# Altium schematic sheet Sheet1.SchDoc(Sheet1)
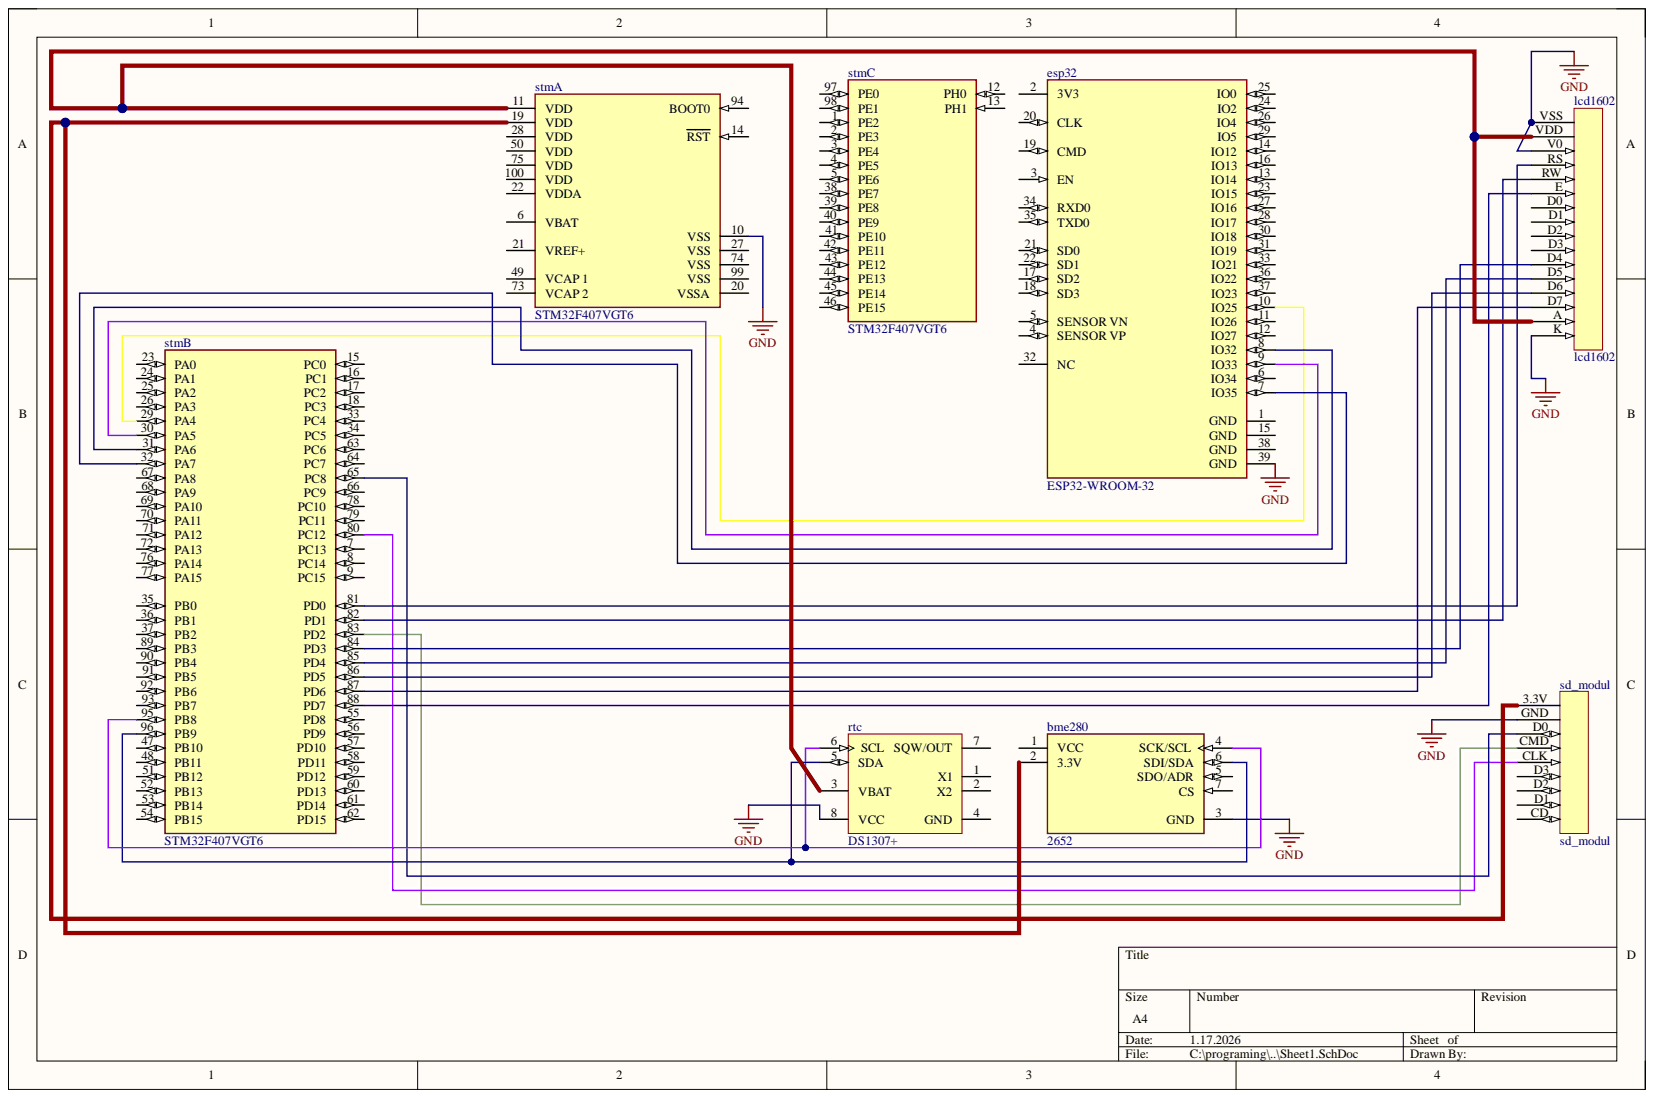
[diagram p0: Sheet1.SchDoc]

## Parts
bme280  2652  [FP-2652-MFG]
esp32  ESP32-WROOM-32  [FP-ESP32-WROOM-32-MFG]
lcd1602  lcd1602  [BGA81C100P9X9_1100X1100X350]
rtc  DS1307+  [MAXM-P8-1]
sd_modul  sd_modul  [BGA81C100P9X9_1100X1100X350]
stm  STM32F407VGT6  [FP-LQFP100-1L-MFG]  (parts A,B,C)

## Nets
GND: bme280.3(GND) esp32.39(GND) lcd1602.K lcd1602.V0 lcd1602.VSS rtc.8(VCC) sd_modul.GND stm.10(VSS)
Netbme280_4: bme280.4(SCK/SCL) rtc.6(SCL) stm.95(PB8)
Netbme280_6: bme280.6(SDI/SDA) rtc.5(SDA) stm.96(PB9)
Netesp32_7: esp32.7(IO35) stm.32(PA7)
Netesp32_8: esp32.8(IO32) stm.31(PA6)
Netesp32_9: esp32.9(IO33) stm.30(PA5)
Netesp32_10: esp32.10(IO25) stm.29(PA4)
Netlcd1602_D4: lcd1602.D4 stm.84(PD3)
Netlcd1602_D5: lcd1602.D5 stm.85(PD4)
Netlcd1602_D6: lcd1602.D6 stm.86(PD5)
Netlcd1602_D7: lcd1602.D7 stm.87(PD6)
Netlcd1602_E: lcd1602.E stm.88(PD7)
Netlcd1602_RS: lcd1602.RS stm.81(PD0)
Netlcd1602_RW: lcd1602.RW stm.82(PD1)
Netsd_modul_CLK: sd_modul.CLK stm.80(PC12)
Netsd_modul_CMD: sd_modul.CMD stm.83(PD2)
Netsd_modul_D0: sd_modul.D0 stm.65(PC8)

Not connected: bme280 1(VCC) 2(3.3V) 5(SDO/ADR) 7(CS); esp32 1(GND) 2(3V3) 3(EN) 4(SENSOR_VP) 5(SENSOR_VN) 6(IO34) 11(IO26) 12(IO27) 13(IO14) 14(IO12) 15(GND) 16(IO13) 17(SD2) 18(SD3) 19(CMD) 20(CLK) 21(SD0) 22(SD1) 23(IO15) 24(IO2) 25(IO0) 26(IO4) 27(IO16) 28(IO17) 29(IO5) 30(IO18) 31(IO19) 32(NC) 33(IO21) 34(RXD0) 35(TXD0) 36(IO22) 37(IO23) 38(GND); lcd1602 A D0 D1 D2 D3 VDD; rtc 1(X1) 2(X2) 3(VBAT) 4(GND) 7(SQW/OUT); sd_modul 3.3V CD D1 D2 D3; stm 1(PE2) 2(PE3) 3(PE4) 4(PE5) 5(PE6) 6(VBAT) 7(PC13) 8(PC14) 9(PC15) 11(VDD) 12(PH0) 13(PH1) 14(RST) 15(PC0) 16(PC1) 17(PC2) 18(PC3) 19(VDD) 20(VSSA) 21(VREF+) 22(VDDA) 23(PA0) 24(PA1) 25(PA2) 26(PA3) 27(VSS) 28(VDD) 33(PC4) 34(PC5) 35(PB0) 36(PB1) 37(PB2) 38(PE7) 39(PE8) 40(PE9) 41(PE10) 42(PE11) 43(PE12) 44(PE13) 45(PE14) 46(PE15) 47(PB10) 48(PB11) 49(VCAP_1) 50(VDD) 51(PB12) 52(PB13) 53(PB14) 54(PB15) 55(PD8) 56(PD9) 57(PD10) 58(PD11) 59(PD12) 60(PD13) 61(PD14) 62(PD15) 63(PC6) 64(PC7) 66(PC9) 67(PA8) 68(PA9) 69(PA10) 70(PA11) 71(PA12) 72(PA13) 73(VCAP_2) 74(VSS) 75(VDD) 76(PA14) 77(PA15) 78(PC10) 79(PC11) 89(PB3) 90(PB4) 91(PB5) 92(PB6) 93(PB7) 94(BOOT0) 97(PE0) 98(PE1) 99(VSS) 100(VDD)

## Library data of the parts
bme280 = CMP-144240-000001-1: SENSOR HUM/PRESS I2C/SPI BME280; Evaluation Kit: Yes; Interface: I2C; Sensor Type: Temperature; Component Kind: Standard; Library Name: Altium Content Vault; Package Quantity: 1
esp32 = CMP-194065-000008-1: RX TXRX MOD WIFI SURFACE MOUNT; Data Rate: 150Mbps; Frequency: 2.5GHz; Operating Supply Voltage: 3.6V; Sensitivity: -98dBm; Case/Package: SMD/SMT; Component Kind: Standard; Library Name: Altium Content Vault; Max Operating Temperature: 85°C; Min Operating Temperature: -40°C; Mount: Surface Mount; Package Quantity: 550; Packaging: Cut Tape; Weight: 0.088185oz
lcd1602 = CMP-010-00024-1: Component Kind: Standard; Library Name: Rostyslav Hrytsak
rtc = CMP-016-00004-3: I2C Real-Time Clock, 5 V, 0 to 70 degC, 8-Pin DIP, RoHS, Tube; Manufacturer: Maxim; Interface: Serial; Max Supply Voltage: 5.5V; Min Supply Voltage: 4.5V; Nominal Supply Voltage: 5V; Package Version: Rev. E, 04/2011; Case/Package: DIP; Component Kind: Standard; Datasheet URL: https://datasheet.ciiva.com/20167/82-0567-20167410.pdf; Datasheet Version: Rev. 100208, 10/2008; Manufacturer URL: http://www.maximintegrated.com/; Max Operating Temperature: 70°C; Min Operating Temperature: 0°C; Mounting Technology: TH; Package Description: 8-Pin Plastic Dual-In-Line, Narrow (0.3in) (P8-1), Body 9.375 x 6.985 mm, Pitch 2.54 mm; Package Quantity: 50; Package Reference: P8-1; Package URL: http://pdfserv.maximintegrated.com/package_dwgs/21-0043.PDF; Packaging: Rail/Tube; Pins: 8; RoHS Compliant: True; Library Name: Rostyslav Hrytsak
sd_modul = CMP-010-00025-1: Component Kind: Standard; Library Name: Rostyslav Hrytsak
stm = CMP-0243-00017-5: Manufacturer Package Identifier: 1L_ME_V5; NexarPartId: 20570110; Address Bus Width: 32b; Core Architecture: ARM Cortex-M4; Flash Memory Size: 1MB; Frequency: 168MHz; Interface: CAN, Ethernet, I2C, I2S, IrDA, LIN, SPI, UART, USART, USB; Max Junction Temperature (Tj): 125°C; Max Supply Voltage: 3.6V; Memory Type: FLASH; Min Supply Voltage: 1.8V; Nominal Supply Current: 1.7µA; Number of A/D Converters: 3; Number of ADC Channels: 16; Number of D/A Converters: 2; Number of Ethernet Channels: 1; Number of I/Os: 82; Number of Timers/Counters: 14; Number of USART Channels: 4; Oscillator Type: Internal; Peripherals: Brown-out Detect/Reset, DMA, I2C, LCD, POR, PWM, WDT; RAM Size: 192kB; Watchdog Timer: Yes; Ambient Temperature Range High: 85°C; Case/Package: LQFP; Component Kind: Standard; Data Bus Width: 32b; Height: 1.45mm; Lead Free: Lead Free; Length: 14.2mm; Library Name: Altium Content Vault; Lifecycle Status: Production; Max Frequency: 168MHz; Max Operating Temperature: 85°C; Memory Size: 1MB; Min Operating Temperature: -40°C; Number of Channels: 16; Number of I2C Channels: 3; Number of Pins: 100; Number of Programmable I/O: 82; Number of PWM Channels: 2; Number of SPI Channels: 3; Number of UART Channels: 2; Number of USB Channels: 1; Radiation Hardening: No; REACH SVHC: No SVHC; RoHS: Compliant; Schedule B: 8542310000, 8542310000; Width: 14.2mm
